# Altium schematic sheet [4]-MCU_POWER.SchDoc([4]-MCU_POWER)
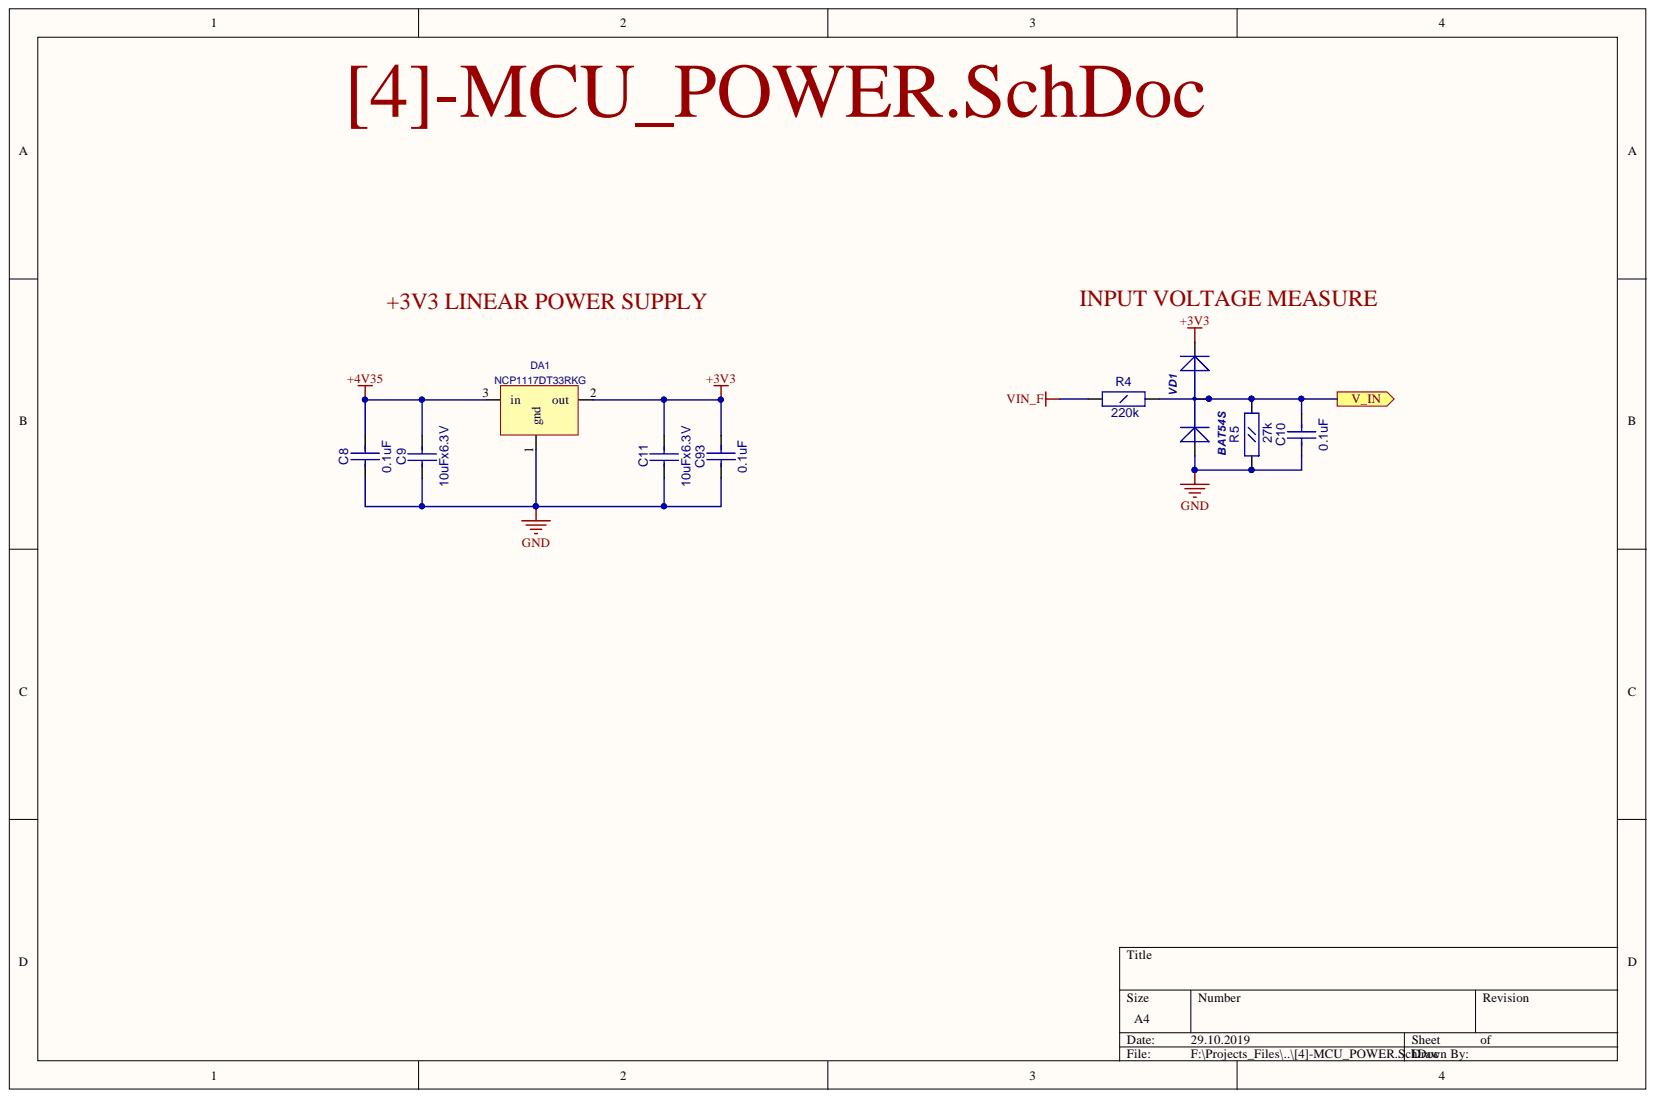
[diagram p0: [4]-MCU_POWER.SchDoc]

## Parts
C8  0.1uF  [CC0603]
C9  10uFx6.3V  [CC0805]
C10  0.1uF  [CC0603]
C11  10uFx6.3V  [CC0805]
C93  0.1uF  [CC0603]
DA1  NCP1117DT33RKG  [SOT-223]
R4  220k  [RC1206]
R5  27k  [RC0603]
VD1  BAT54S  [SOT-23(GSD)]

## Ports
V_IN

## Nets
+3V3: C11.2 C93.1 DA1.2 VD1.2
+4V35: C8.1 C9.2 DA1.3
GND: C8.2 C9.1 C10.1 C11.1 C93.2 DA1.1 R5.1 VD1.1
VIN_F: R4.1
V_IN: C10.2 R4.2 R5.2 VD1.3 ; port V_IN

## Library data of the parts
C8 C10 C93 = CC0603: Value: 0.1uF; Description: CC0603; Component Kind: Standard; Library Name: gprs_modem_2.SchLib
C9 C11 = CC0805: Value: 10uFx6.3V; Description: CC0805; Component Kind: Standard; Library Name: gprs_modem_2.SchLib
DA1 = NCP1117DT33RKG: Linear Regulator 3.3V; Component Kind: Standard; Library Name: fizik_lib.SchLib
R4 = RC1206: Value: 220k; Description: RC1206; Component Kind: Standard; Library Name: fizik_lib.SchLib
R5 = RC0603: Value: 27k; Description: RC0603; Component Kind: Standard; Library Name: gprs_modem_2.SchLib
VD1 = BAT54S: 1.0 Ampere General Purpose Rectifier; ComponentField6: Published 8-Jun-2000, Modified 11-July-2000: SIM Model Added.; Simulation: DIODE; Component Kind: Standard; Library Name: gprs_modem_2.SchLib
